# Altium schematic sheet ZeroCrossDetector.SchDoc
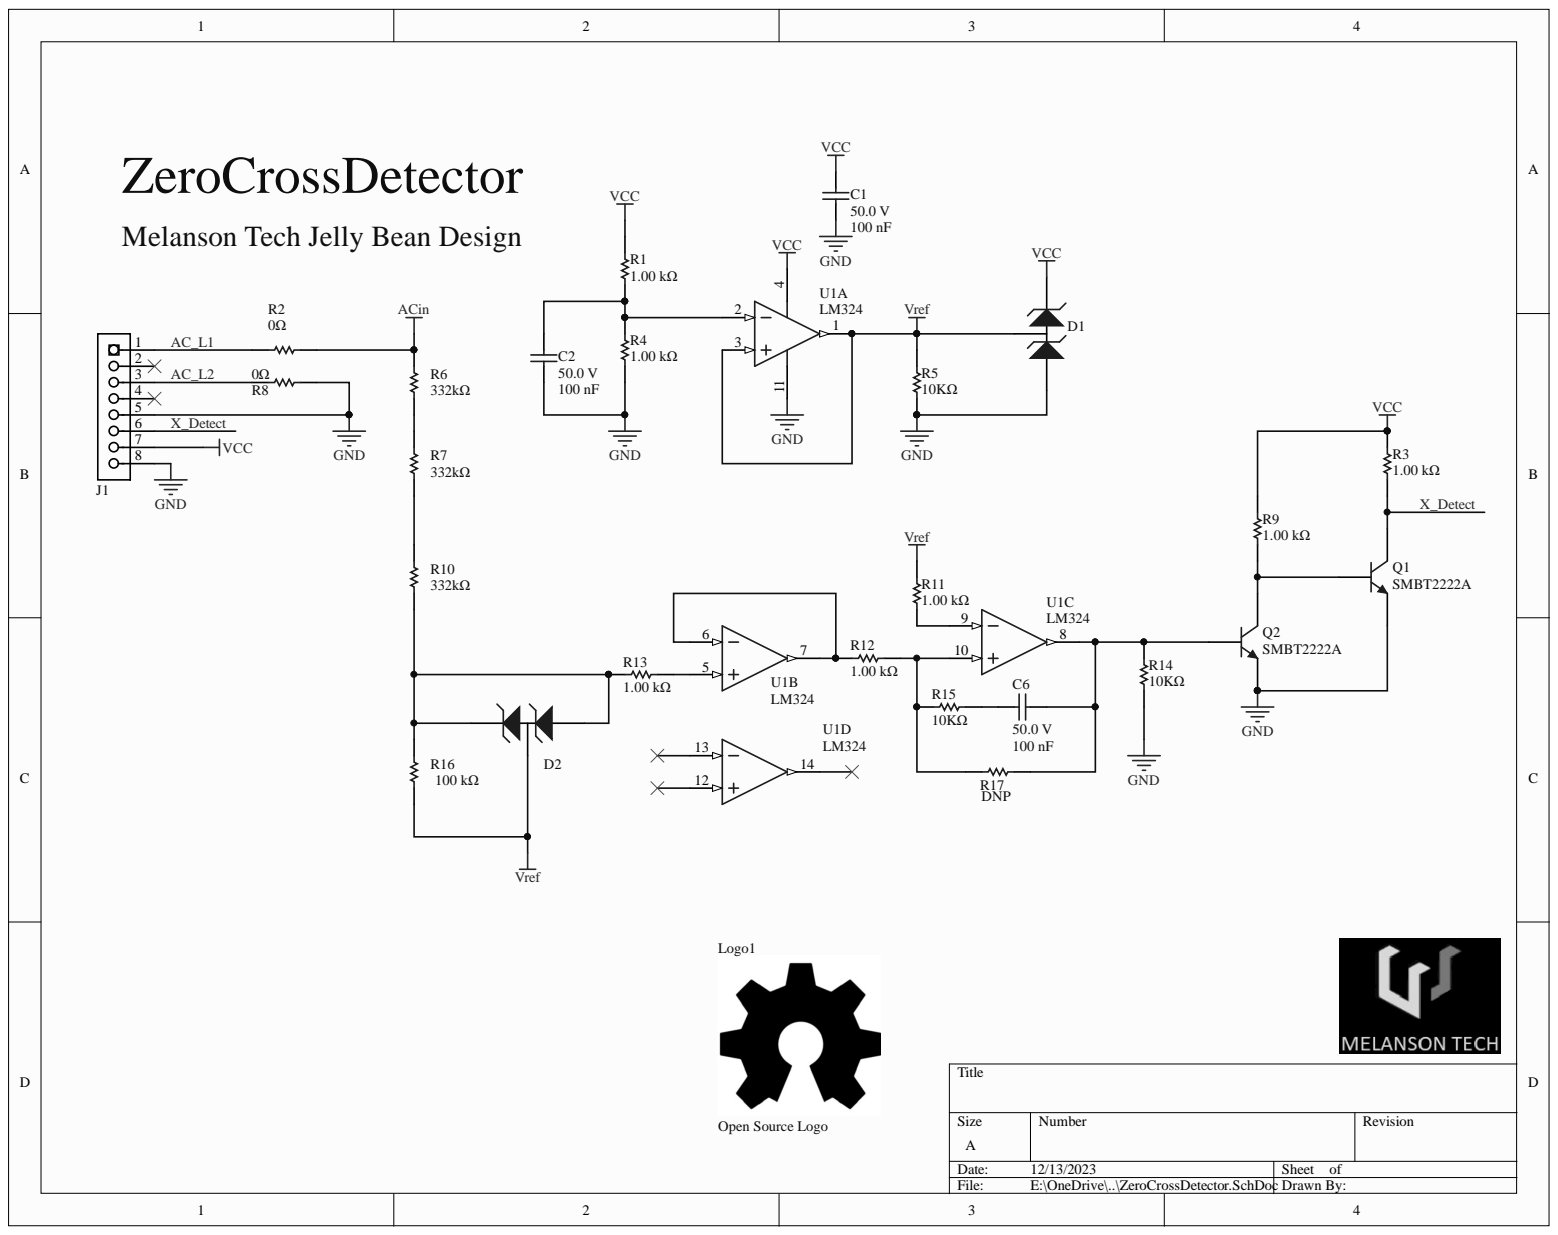
[diagram p0: ZeroCrossDetector.SchDoc]

## Parts
C1  08055C104JAT2A  [Cap,0805]  (value not drawn)
C2  08055C104JAT2A  [Cap,0805]  (value not drawn)
C6  Voltage Rating (DC): 50.0 V  [Cap,0805]  (hidden comment: 08055C104JAT2A)
D1  BAT54SLT1G  [SOT23-3]  (value not drawn)
D2  BAT54SLT1G  [SOT23-3]  (value not drawn)
J1  HEADER,0.1",8Pin,SinlgeRow  [Header,8Pin,SingleRow, RA,0.1"]  (value not drawn)
Logo1  Open Source Logo  [Symbol,Open Source]
Q1  SMBT2222A  [SOT23-3]
Q2  SMBT2222A  [SOT23-3]
R1  Vishay CRCW08051K00JNEA  [res, 0805, HD]  (value not drawn)
R2  Resistance: 0Ω  [Res,1206]  (hidden comment: Res1)
R3  Vishay CRCW08051K00JNEA  [res, 0805, HD]  (value not drawn)
R4  Vishay CRCW08051K00JNEA  [res, 0805, HD]  (value not drawn)
R5  Resistance: 10KΩ  [res, 0805, HD]  (hidden comment: Vishay / Dale CRCW080510K0FKTA)
R6  Resistance: 332kΩ  [res, 0805, HD]  (hidden comment: RT0805BRD07332KL)
R7  Resistance: 332kΩ  [res, 0805, HD]  (hidden comment: RT0805BRD07332KL)
R8  Resistance: 0Ω  [Res,1206]  (hidden comment: Res1)
R9  Vishay CRCW08051K00JNEA  [res, 0805, HD]  (value not drawn)
R10  Resistance: 332kΩ  [res, 0805, HD]  (hidden comment: RT0805BRD07332KL)
R11  Vishay CRCW08051K00JNEA  [res, 0805, HD]  (value not drawn)
R12  Resistance: 1.00 kΩ  [res, 0805, HD]  (hidden comment: Vishay CRCW08051K00JNEA)
R13  Resistance: 1.00 kΩ  [res, 0805, HD]  (hidden comment: Vishay CRCW08051K00JNEA)
R14  Resistance: 10KΩ  [res, 0805, HD]  (hidden comment: Vishay / Dale CRCW080510K0FKTA)
R15  Resistance: 10KΩ  [res, 0805, HD]  (hidden comment: Vishay / Dale CRCW080510K0FKTA)
R16  CRCW0805100KFKEA  [res, 0805, HD]  (value not drawn)
R17  DNP  [res, 0805, HD]
U1  Mfg P/N: LM324  [SOIC-14]  (hidden comment: LM324ADR2G)  (parts A,B,C,D)

## Nets
ACIN: R2.2 R6.2
GND: C1.1 C2.1 D1.1 J1.5 J1.8 Q1.2 Q2.2 R4.2 R5.1 R8.1 R14.1 U1.11
VCC: C1.2 D1.2 J1.7 R1.1 R3.2 R9.2 U1.4
VREF: D1.3 D2.3 R5.2 R11.2 R16.1 U1.1 U1.3
AC_L1: J1.1 R2.1
AC_L2: J1.3 R8.2
X_DETECT: J1.6 Q1.3 R3.1
NetC2_2: C2.2 R1.2 R4.1 U1.2
NetC6_1: C6.1 Q2.1 R14.2 R17.1 U1.8
NetC6_2: C6.2 R15.2
NetD2_1: D2.1 D2.2 R10.1 R13.1 R16.2
NetQ1_1: Q1.1 Q2.3 R9.1
NetR6_1: R6.1 R7.2
NetR7_1: R7.1 R10.2
NetR11_1: R11.1 U1.9
NetR12_1: R12.1 U1.6 U1.7
NetR12_2: R12.2 R15.1 R17.2 U1.10
NetR13_2: R13.2 U1.5

Not connected: J1 2 4; U1 12 13 14

## Library data of the parts
C1 C2 = Cap,0805,100nf,50V,5%,08055C104JAT2A: Capacitor; Ceramic; Cap 0.1 uF; Tol 5%; Vol-Rtg 50 VDC; SMT; 0805; X7R; Tape & Reel; Capacitance: 100 nF; Case/Package (SI): 2012; Detailed Description: 0.1µF ±5% 50V Ceramic Capacitor X7R 0805 (2012 Metric); Insulation Resistance: 100 GΩ; Lead-Free Status: Lead Free; Voltage Rating (DC): 50.0 V; Capacitance Tolerance: ±5%; Case/Package: 0805; Component Kind: Standard; Dielectric Characteristic: X7R; digikey url: http://www.digikey.ca/product-detail/en/avx-corporation/08055C104JAT2A/478-3352-1-ND/930144; Manufacturer Part Number: 08055C104JAT2A; Mounting Style: Surface Mount; Number of Pins: 2; Octopart URL: http://octopart.com/08055c104jat2a-avx-55388010; Operating Temperature: -55°C ~ 125°C; Packaging: Tape & Reel (TR), Cut Tape (CT); REACH SVHC Compliance: No SVHC; RoHS: Compliant; Size-Height: 710 µm; Size-Length: 2.01 mm; Size-Thickness: 940 µm; Size-Width: 1.24 mm; Supplier 1: Digi-Key; Supplier Part Number 1: 478-3352-1-ND; Library Name: Master Nov2021.SchLib
C6 = Cap,0805,100nf,50V,5%,08055C104JAT2A: Capacitor; Ceramic; Cap 0.1 uF; Tol 5%; Vol-Rtg 50 VDC; SMT; 0805; X7R; Tape & Reel; Capacitance: 100 nF; Case/Package (SI): 2012; Detailed Description: 0.1µF ±5% 50V Ceramic Capacitor X7R 0805 (2012 Metric); Insulation Resistance: 100 GΩ; Lead-Free Status: Lead Free; Capacitance Tolerance: ±5%; Case/Package: 0805; Component Kind: Standard; Dielectric Characteristic: X7R; digikey url: http://www.digikey.ca/product-detail/en/avx-corporation/08055C104JAT2A/478-3352-1-ND/930144; Manufacturer Part Number: 08055C104JAT2A; Mounting Style: Surface Mount; Number of Pins: 2; Octopart URL: http://octopart.com/08055c104jat2a-avx-55388010; Operating Temperature: -55°C ~ 125°C; Packaging: Tape & Reel (TR), Cut Tape (CT); REACH SVHC Compliance: No SVHC; RoHS: Compliant; Size-Height: 710 µm; Size-Length: 2.01 mm; Size-Thickness: 940 µm; Size-Width: 1.24 mm; Supplier 1: Digi-Key; Supplier Part Number 1: 478-3352-1-ND; Library Name: Master Nov2021.SchLib
D1 D2 = Diode,Shottky,Array,Series,30V,200mA,BAT54SLT1G: Diode Array 1 Pair Series Connection Schottky 30V 200mA (DC) Surface Mount TO-236-3, SC-59, SOT-23-3; Manufacturer: ON Semiconductor; Current - Reverse Leakage @ Vr: 2µA @ 25V; Reverse Recovery Time (trr): 5ns; Speed: Small Signal =< 200mA (Io), Any Speed; Voltage - Forward (Vf) (Max) @ If: 800mV @ 100mA; Base Part Number: BAT54SL; Component Kind: Standard; Current - Average Rectified (Io) (per Diode): 200mA (DC); Detailed Description: Diode Array 1 Pair Series Connection Schottky 30V 200mA (DC) Surface Mount TO-236-3, SC-59, SOT-23-3; Diode Configuration: 1 Pair Series Connection; Diode Type: Schottky; mfg P/N: BAT54SLT1G; Mounting Type: Surface Mount; Operating Temperature - Junction: 125°C (Max); Package / Case: TO-236-3, SC-59, SOT-23-3; Supplier 1: Digi-Key; Supplier 2: LCSC; Supplier Device Package: SOT-23-3 (TO-236); Supplier Part Number 1: BAT54SLT1GOSCT-ND; Supplier Part Number 2: C19726; Voltage - DC Reverse (Vr) (Max): 30V; Library Name: Master Nov2021.SchLib
Logo1 = Symbol,Open Source: Open Source Silk Screen Logo; Component Kind: Standard; Library Name: Master Nov2021.SchLib
Q1 Q2 = Trans,40V,600mA,0.33W, SMBT2222A: NPN Silicon Switching Transistor, 40 V VCEO, 600 mA IC, SOT23, Reel, Green; Current - Collector Cutoff (Max): 10nA (ICBO); DC Current Gain (hFE) (Min) @ Ic, Vce: 100 @ 150mA, 10V; Frequency - Transition: 300MHz; Power Dissipation: 0.33 W; Vce Saturation (Max) @ Ib, Ic: 1V @ 50mA, 500mA; Voltage - Collector Emitter Breakdown (Max): 40V; Component Kind: Standard; mfg P/N: SMBT2222A; Mounting Style: Surface Mount; Mounting Type: Surface Mount; Number of Pins: 3; Package / Case: TO-236-3, SC-59, SOT-23-3; Packaging: Cut Tape (CT); Polarity: NPN; REACH SVHC Compliance: No SVHC; RoHS: Compliant; Supplier 1: Digi-Key; Supplier 2: Digi-Key; Supplier 3: Digi-Key; Supplier 4: LCSC; Supplier Device Package: SOT-23-3; Supplier Part Number 1: SMBT2222AE6327HTSA1CT-ND; Supplier Part Number 2: MMBT2222ALT1HTSA1CT-ND; Supplier Part Number 3: SMBT2222AE6327HTSA1DKR-ND; Supplier Part Number 4: C151510; Library Name: Master Nov2021.SchLib
R1 R3 R4 R9 R11 = Res,0805,1.00 kΩ,5%,200ppm,CRCW08051K00JNEA: Res Thick Film 0805 1K Ohm 5% 0.125W(1/8W) ±200ppm/C Molded SMD Automotive Paper T/R; Case/Package (SI): 2012; Lead-Free Status: Lead Free; Power Rating: 125 mW; Resistance: 1.00 kΩ; Temperature Coefficient: 200 ppm/°C; Voltage Rating (DC): 150 V; Case/Package: 0805; Component Kind: Standard; Manufacturer Part Number: CRCW08051K00JNEA; Mounting Style: Surface Mount; Number of Pins: 2; Packaging: Tape & Reel (TR), Cut Tape (CT); REACH SVHC Compliance: No SVHC; Resistance Tolerance: ±5%; RoHS: Compliant; Size-Height: 450 µm; Size-Length: 2.00 mm; Size-Width: 1.25 mm; Supplier 1: Digi-Key; Supplier 2: Digi-Key; Supplier Part Number 1: 541-1.0KADKR-ND; Supplier Part Number 2: 541-1.0KACT-ND; Library Name: Master Nov2021.SchLib
R2 R8 = Res,1206,Generic: Component Kind: Standard; Library Name: Master Nov2021.SchLib
R5 R14 R15 = Res,0805,10.0kΩ,1%,100 ppm,CRCW080510K0FKTA: RES SMD 10K OHM 1% 1/8W 0805; Case/Package (SI): 2012; Lead-Free Status: Contains Lead; Power Dissipation: 0.125 W; Temperature Coefficient: 100.0 ppm/C; Voltage Rating (DC): 150.0 V; Case/Package: 0805; Component Kind: Standard; Mounting Style: Surface Mount; Number of Pins: 2; Power Rating: 0.125 W; REACH SVHC Compliance: No SVHC; Resistance Tolerance: 1%; RoHS: Non-Compliant; Size-Height: 0.00045 m; Size-Length: 0.002 m; Size-Width: 0.00125 m; Supplier 1: Digi-Key; Supplier Part Number 1: 541-3016-1-ND; Library Name: Master Nov2021.SchLib
R6 R7 R10 = Res,0805,332k,0.1%,±25ppm/°C,RT0805BRD07332KL: Thin Film Resistors - SMD 1/8W 332K ohm .1% 25ppm; Lead-Free Status: Lead Free; Power Rating: 0.125 W; Resistance Tolerance: ±0.1%; Voltage Rating (DC): 150.0 V; Case/Package: 0805; Component Kind: Standard; mfg P/N: RT0805BRD07332KL; Mounting Style: Surface Mount; RoHS: Compliant; Size-Height: 0.0005 m; Size-Length: 0.002 m; Size-Width: 0.00125 m; Supplier 1: Digi-Key; Supplier Part Number 1: YAG1869CT-ND; Temperature Coefficient: ±25ppm/°C; Library Name: Master Nov2021.SchLib
R12 R13 = Res,0805,1.00 kΩ,5%,200ppm,CRCW08051K00JNEA: Res Thick Film 0805 1K Ohm 5% 0.125W(1/8W) ±200ppm/C Molded SMD Automotive Paper T/R; Case/Package (SI): 2012; Lead-Free Status: Lead Free; Power Rating: 125 mW; Temperature Coefficient: 200 ppm/°C; Voltage Rating (DC): 150 V; Case/Package: 0805; Component Kind: Standard; Manufacturer Part Number: CRCW08051K00JNEA; Mounting Style: Surface Mount; Number of Pins: 2; Packaging: Tape & Reel (TR), Cut Tape (CT); REACH SVHC Compliance: No SVHC; Resistance Tolerance: ±5%; RoHS: Compliant; Size-Height: 450 µm; Size-Length: 2.00 mm; Size-Width: 1.25 mm; Supplier 1: Digi-Key; Supplier 2: Digi-Key; Supplier Part Number 1: 541-1.0KADKR-ND; Supplier Part Number 2: 541-1.0KACT-ND; Library Name: Master Nov2021.SchLib
R16 = Res,0805,100kΩ,1%,100ppm,CRCW0805100KFKEA: 100 kOhms ±1% 0.125W, 1/8W Chip Resistor 0805 (2012 Metric) Thick Film; Manufacturer: Vishay; Case/Package (SI): 2012; Lead-Free Status: Lead Free; Power Rating: 125 mW; Resistance: 100 kΩ; Temperature Coefficient: -100 ppm/°C to 100 ppm/°C; Case/Package: 0805; Component Kind: Standard; Manufacturer Part Number: CRCW0805100KFKEA; Number of Pins: 2; Operating Temperature: 150 °C (max); Packaging: Tape & Reel (TR), Reel, Cut Tape (CT); Resistance Tolerance: ±1%; RoHS: Compliant; Size-Height: 450 µm; Size-Length: 2.00 mm; Size-Width: 1.25 mm; Supplier 1: RS; Supplier 1 Part Number: 679-0803; Supplier 2: Digi-Key; Supplier Part Number 2: 541-100KCCT-ND; Library Name: Master Nov2021.SchLib
R17 = Res,0805,Generic: Component Kind: Standard; Resistance: ?; Library Name: Master Nov2021.SchLib
U1 = OPAMP, Quad circuit, 1Mhz, SOIC-14,SMD, LM324ADR2G: Single Supply Quad Operational Amplifier, 3 to 32 V, 0 to 70 degC, 14-Pin SOIC, Pb-Free, Tape and Reel; Bandwidth: 1MHz; Lead-Free Status: Lead Free; Number of Channels: 4; Slew Rate: 0.6 V/μs; Case/Package: SOIC; Component Kind: Standard; Halogen Free Status: Halogen Free; Lifecycle Status: Active; Mounting Style: Surface Mount; Number of Circuits: 4; Number of Pins: 14; Packaging: Cut Tape (CT); RoHS: Compliant; Supplier 1: Digi-Key; Supplier 2: LCSC; Supplier Part Number 1: LM324ADR2GOSCT-ND; Supplier Part Number 2: C81465; Library Name: Master Nov2021.SchLib
J1 = HEADER,0.1",8Pin,SinlgeRow: Component Kind: Standard; Supplier 1: Digi-Key; Supplier Part Number 1: S1011E-36-ND; Library Name: Master Nov2021.SchLib
